# Altium schematic sheet RSPB-Tracker.SchDoc(U_RSPB-Tracker)
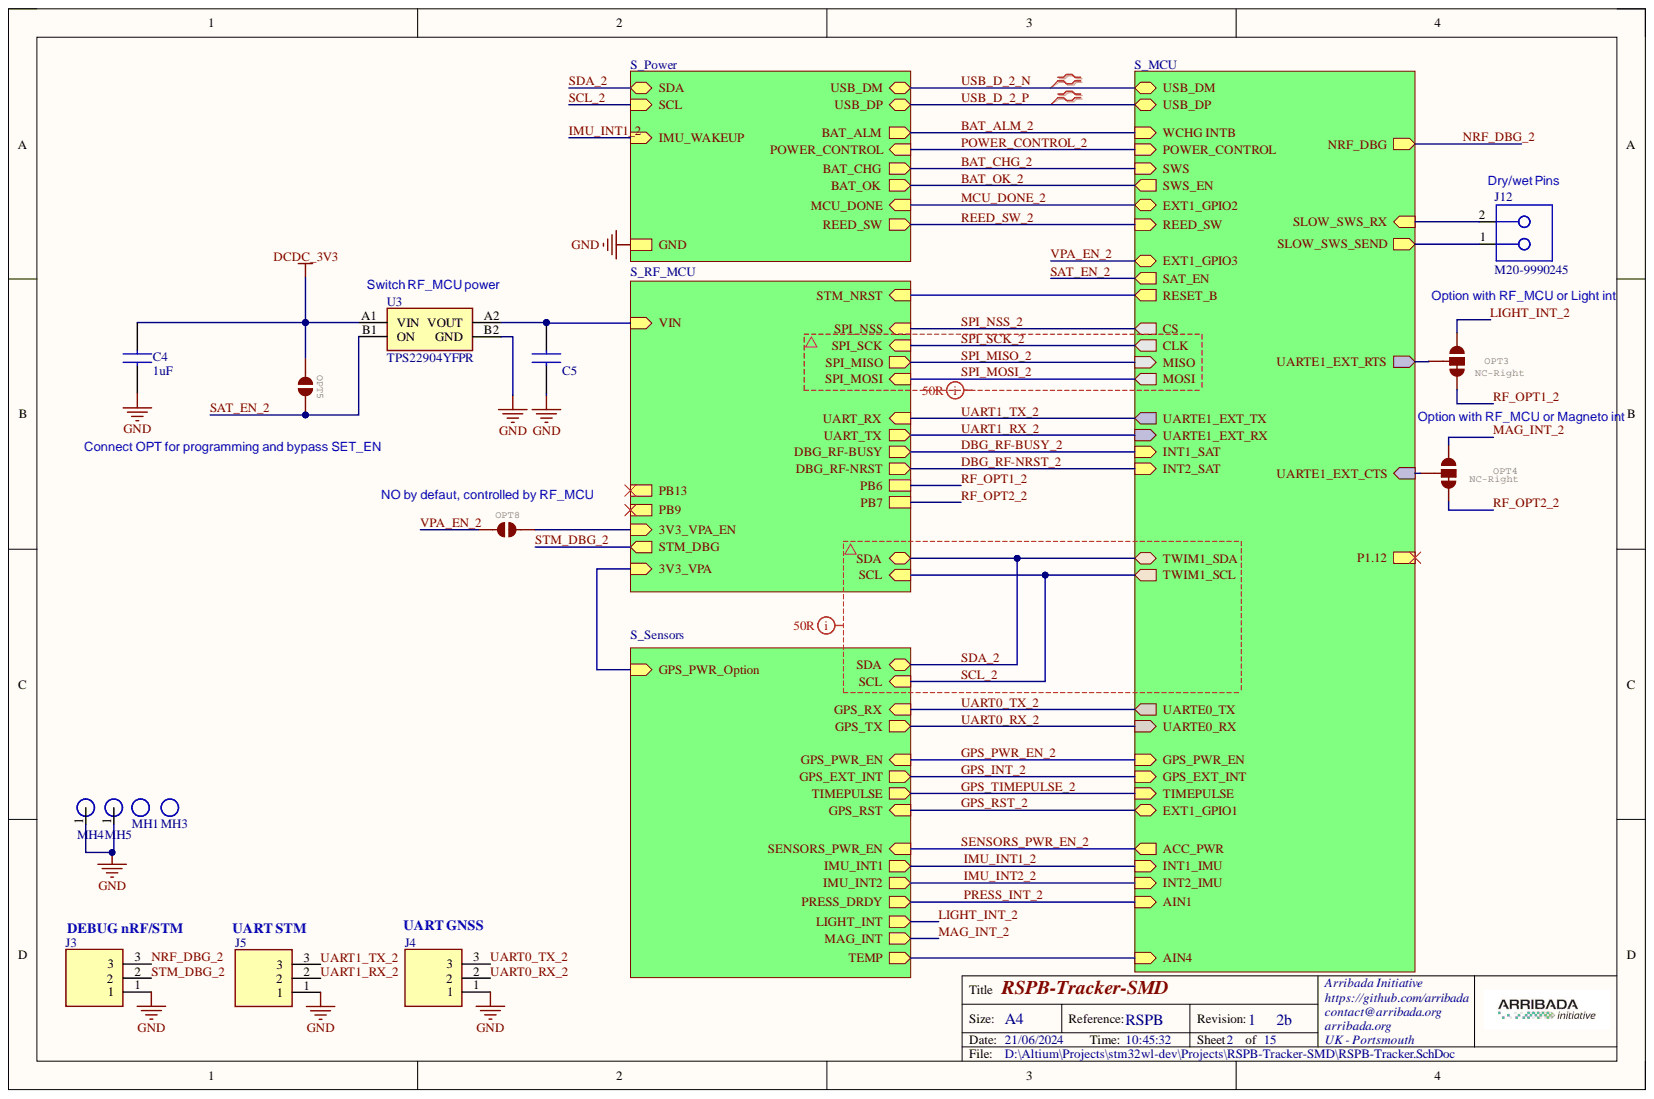
[diagram p0: RSPB-Tracker.SchDoc]

## Parts
C4  1uF  [CAPC1005X55N]
C5  GRM155R71C104KA88D  [0402]  (value not drawn)
J3  860-10-003-10-001101  [8601000310001101]  (value not drawn)
J4  860-10-003-10-001101  [8601000310001101]  (value not drawn)
J5  860-10-003-10-001101  [8601000310001101]  (value not drawn)
J12  M20-9990245  [HDR 2POS 2.54MM THRU HOLE]
MH1  Mounting Hole M2  [MOUNTING HOLE M2 UNPLATED]  (value not drawn)
MH3  Mounting Hole M2  [MOUNTING HOLE M2 UNPLATED]  (value not drawn)
MH4  Mounting Hole M2 Plated  [Mounting Hole M2 Plated]  (value not drawn)
MH5  Mounting Hole M2 Plated  [Mounting Hole M2 Plated]  (value not drawn)
OPT3  NC-Right  [SMT-JUMPER_3_2-NCRIGHT_TRACE_SILK]
OPT4  NC-Right  [SMT-JUMPER_3_2-NCRIGHT_TRACE_SILK]
OPT5  NO  [SMT-JUMPER_2_NO_TRACE_SILK]  (value not drawn)
OPT8  NO  [SMT-JUMPER_2_NO_TRACE_SILK]  (value not drawn)
U3  TPS22904YFPR  [BGA4C40P2X2_77X77X50]

## Nets
DCDC_3V3: C4.2 OPT5.1 U3.A1(VIN)
GND: C4.1 C5.1 J3.1 J4.1 J5.1 MH4.1 MH5.1 U3.B2(GND)
LIGHT_INT_2: OPT3.1
MAG_INT_2: OPT4.1
NRF_DBG_2: J3.3
RF_OPT1_2: OPT3.3
RF_OPT2_2: OPT4.3
SAT_EN_2: OPT5.2 U3.B1(ON)
STM_DBG_2: J3.2
UART0_RX_2: J4.2
UART0_TX_2: J4.3
UART1_RX_2: J5.2
UART1_TX_2: J5.3
VPA_EN_2: OPT8.1
NetC5_2: C5.2 U3.A2(VOUT)
NetJ12_1: J12.1
NetJ12_2: J12.2
NetOPT3_2: OPT3.2
NetOPT4_2: OPT4.2
NetOPT8_2: OPT8.2
3V3_VPA_2:
AIN4_2:
BAT_ALM_2:
BAT_CHG_2:
BAT_OK_2:
DBG_RF-BUSY_2:
DBG_RF-NRST_2:
GPS_INT_2:
GPS_PWR_EN_2:
GPS_RST_2:
GPS_TIMEPULSE_2:
IMU_INT1_2:
IMU_INT2_2:
MCU_DONE_2:
P1.12_2:
PB9_2:
PB13_2:
POWER_CONTROL_2:
PRESS_INT_2:
REED_SW_2:
RESET_B_2:
SCL_2:
SDA_2:
SENSORS_PWR_EN_2:
SPI_MISO_2:
SPI_MOSI_2:
SPI_NSS_2:
SPI_SCK_2:
USB_D_2_N:
USB_D_2_P:

## Library data of the parts
C4 = GRM155Z71A105KE01J: Multilayer Ceramic Capacitors MLCC - SMD/SMT 1.0UF 10V 10% 0402; Manufacturer 1: Murata; Manufacturer_Name: Murata Electronics; Description 1: Multi-Layer Ceramic Capacitor 1uF X7R 10% 0402 Paper T/R; Arrow Part Number: GRM155Z71A105KE01J; Arrow Price/Stock: https://www.arrow.com/en/products/grm155z71a105ke01j/murata-manufacturing?region=nac; Category 1: Ceramic Capacitors; Category 2: Ceramic Capacitors; Component Kind: Standard; Datasheet Link: http://www.murata.com/~/media/webrenewal/support/library/catalog/products/capacitor/mlcc/c02e.pdf; Description 2: Multi-Layer Ceramic Capacitor 1uF X7R 10% 0402 Paper T/R; Height: 0.55mm; Library Name: stm32wl-dev.SchLib; Manufacturer 2: Murata; Manufacturer Part Number 1: GRM155Z71A105KE01J; Manufacturer Part Number 2: GRM155Z71A105KE01J; Manufacturer_Part_Number: GRM155Z71A105KE01J; Mouser Part Number: 81-GRM155Z71A105KE1J; Mouser Price/Stock: https://www.mouser.co.uk/ProductDetail/Murata-Electronics/GRM155Z71A105KE01J?qs=xZ%2FP%252Ba9zWqaF%2FmyRgV0iOA%3D%3D; Pricing 1: 1=0,1, 10=0,069, 50=0,069, 100=0,031, 1000=0,018, 10000=0,013 (USD); Pricing 2: 1=0,1, 10=0,063, 50=0,0344, 100=0,0281, 500=0,02006, 1000=0,01577, 2500=0,01433, 5000=0,01319 (USD); RoHS 1: Yes; RoHS 2: Yes; Stock 1: 804340; Stock 2: 80025; Supplier 1: Mouser; Supplier 2: Digi-Key; Supplier Part Number 1: 81-GRM155Z71A105KE1J; Supplier Part Number 2: 490-GRM155Z71A105KE01JCT-ND; Value: 1uF
C5 = GRM155R71C104KA88D: Multilayer Ceramic Capacitors MLCC - SMD/SMT 0.1 uF 16 VDC 10% 0402 X7R; Manufacturer: YAGEO; Manufacturer 1: Murata; Manufacturer_Part_Number: CC0402KRX7R7BB104; Capacitance: 100nF; Description 1: 0402 0.1 uF 16V ±10 % Tolerance X7R SMT Multilayer Ceramic Capacitor; Value: 100nF; Voltage: 16V; Case Code (Imperial): 0402; Case Code (Metric): 1005; Case/Package: 0402; Category 1: Ceramic Capacitors; Component Kind: Standard; ComponentLink1Description: https://datasheet.ciiva.com/129/grm155r71c104ka88-01-129739.pdf?src-supplier=Digi-Key; ComponentLink1URL: https://datasheet.ciiva.com/129/grm155r71c104ka88-01-129739.pdf?src-supplier=Digi-Key; ComponentLink2Description: https://datasheet.ciiva.com/129/grm155r71c104ka88-01-129740.pdf?src-supplier=Digi-Key; ComponentLink2URL: https://datasheet.ciiva.com/129/grm155r71c104ka88-01-129740.pdf?src-supplier=Digi-Key; ComponentLink3Description: https://datasheet.ciiva.com/29199/454098-da-01-en-vs-kondensator-0402-29199799.pdf?src-supplier=Conrad; ComponentLink3URL: https://datasheet.ciiva.com/29199/454098-da-01-en-vs-kondensator-0402-29199799.pdf?src-supplier=Conrad; ComponentLink4Description: https://datasheet.ciiva.com/7937/4360-7937615.pdf?src-supplier=Element14; ComponentLink4URL: https://datasheet.ciiva.com/7937/4360-7937615.pdf?src-supplier=Element14; ComponentLink5Description: https://datasheet.ciiva.com/14116/grmseries-highdielectrictype-eng-14116878.pdf?src-supplier=Distrelec; ComponentLink5URL: https://datasheet.ciiva.com/14116/grmseries-highdielectrictype-eng-14116878.pdf?src-supplier=Distrelec; ComponentLink6Description: https://datasheet.ciiva.com/14103/grmseries-highdielectrictype-eng-14103750.pdf?src-supplier=Distrelec; ComponentLink6URL: https://datasheet.ciiva.com/14103/grmseries-highdielectrictype-eng-14103750.pdf?src-supplier=Distrelec; ComponentLink7Description: https://datasheet.ciiva.com/28534/4360-28534127.pdf?src-supplier=Element14; ComponentLink7URL: https://datasheet.ciiva.com/28534/4360-28534127.pdf?src-supplier=Element14; ComponentLink8Description: https://datasheet.ciiva.com/28534/4360-28534133.pdf?src-supplier=Element14; ComponentLink8URL: https://datasheet.ciiva.com/28534/4360-28534133.pdf?src-supplier=Element14; Depth: 0.5mm; Dielectric: X7R; Dielectric Material: Ceramic; Height: 0.5mm; Lead Free: Lead Free; Length: 1mm; Library Name: stm32wl-dev.SchLib; Manufacturer Part Number 1: GRM155R71C104KA88D; Material: Ceramic; Max Operating Temperature: 125°C; Min Operating Temperature: -55°C; Mount: Surface Mount; Number of Pins: 2; Package: C0402; Package Quantity: 1; Packaging: Tape and Reel; Pricing 1: 1=0,1, 10=0,017, 50=0,017, 100=0,01, 1000=0,008, 10000=0,005 (USD); Radiation Hardening: No; Reach SVHC Compliant: Unknown; RoHS 1: Yes; RoHS Compliant: Yes; Series: GRM; Stock 1: 7816691; Supplier 1: Mouser; Supplier Part Number 1: 81-GRM155R71C104KA88; Termination: SMD/SMT; Thickness: 0.022inch; Tolerance: 10%; Voltage Rating: 16V; Voltage Rating (DC): 16V; Width: 0.02inch
J3-J5 = 860-10-003-10-001101: Connector, Header 3 pos, 1 row, pitch 1mm; Manufacturer_Name: Preci-Dip; Component Kind: Standard; Datasheet Link: https://www.precidip.com/en/Products/PCB-Connectors/pview/860-10-NNN-10-001101.html#; Height: 3.63mm; Library Name: stm32wl-dev.SchLib; Manufacturer_Part_Number: 860-10-003-10-001101; Mouser Part Number: 437-8601000310001101; Mouser Price/Stock: https://www.mouser.co.uk/ProductDetail/Preci-dip/860-10-003-10-001101?qs=jrVTBkKbt4ZfyeZ%252Bg9GDNA%3D%3D
J12 = M20-9990245: CON HDR 2POS HEADER 2.54MM VERT THRU HOLE; Manufacturer: Harwin; Component Kind: Standard; Distributor 1: EBV; Distributor 2: Future Electronics; Library Name: Connectors.SchLib; Manufacturers Part Number: M20-9990245; Supplier 1: Digi-Key; Supplier 2: Mouser; Supplier Part Number 1: 952-2261-ND; Supplier Part Number 2: 855-M20-9990245
MH1 MH3 = Mounting Hole M2: Manufacturer: NOT FITTED PART; Component Kind: Standard; Description: Mounting Hole M2; Distributor 1: EBV; Distributor 2: Future Electronics; Library Name: Misc.SchLib; Manufacturers Part Number: NOT FITTED PART
MH4 MH5 = Mounting Hole M2 Plated: Manufacturer: NOT FITTED PART; Component Kind: Standard; Description: Mounting Hole M2 Plated; Distributor 1: EBV; Distributor 2: Future Electronics; Library Name: Misc.SchLib; Manufacturers Part Number: NOT FITTED PART
OPT3 OPT4 = JUMPER-SMT_3_2-NCRIGHT_TRACE_SILK: Normally closed trace jumper (1 of 2 connections)      This jumper has a trace between Right pad so they are normally opened right (NCLEFT). Use a razor knife to open the connection(s). For best results follow the IPC guidelines for cutting traces: Cutout at least 0.063 mm (0.005 in).  Remove all loose material to clean up the cut area.  Seal the cut with an approved epoxy.       Reapply solder to reclose the connection(s).; DeviceName: _SILK; DeviceSetName: JUMPER-SMT_3_2-NC_TRACE; GateName_1: G$1; SymbolName_1: SMT-JUMPER_3_2-NC_TRACE; Component Kind: Standard; Library Name: stm32wl-dev.SchLib; LibraryName: SparkFun-Jumpers
OPT5 OPT8 = JUMPER-SMT_2_NO_TRACE_SILK_1: Normally opened trace jumper      This jumper has no trace between two pads so it's normally closed (NO).; DeviceName: _SILK; DeviceSetName: JUMPER-SMT_2_NC_TRACE; GateName_1: G$1; SymbolName_1: SMT-JUMPER_2_NC_TRACE; Component Kind: Standard; Library Name: stm32wl-dev.SchLib; LibraryName: SparkFun-Jumpers
U3 = TPS22904YFPR: 3.6-V, 0.5-A, 66-mΩ load switch with output discharge 4-DSBGA -40 to 85; Manufacturer: Texas Instruments; Category: Hot Swap Controllers; Component Kind: Standard; ComponentLink1Description: https://datasheet.ciiva.com/15109/getdatasheetpartid-534261-15109132.pdf?src-supplier=Verical; ComponentLink1URL: https://datasheet.ciiva.com/15109/getdatasheetpartid-534261-15109132.pdf?src-supplier=Verical; ComponentLink2Description: https://datasheet.ciiva.com/3232/tps22903-3232832.pdf?src-supplier=Avnet; ComponentLink2URL: https://datasheet.ciiva.com/3232/tps22903-3232832.pdf?src-supplier=Avnet; ComponentLink3Description: https://datasheet.ciiva.com/41462/001014702-da-01-en-ic-load-switch-tps22904yfpr-dsbga-4-tid-41462548.pdf?src-supplier=Conrad; ComponentLink3URL: https://datasheet.ciiva.com/41462/001014702-da-01-en-ic-load-switch-tps22904yfpr-dsbga-4-tid-41462548.pdf?src-supplier=Conrad; ComponentLink4Description: https://datasheet.ciiva.com/3233/tps22903-3233560.pdf?src-supplier=Avnet; ComponentLink4URL: https://datasheet.ciiva.com/3233/tps22903-3233560.pdf?src-supplier=Avnet; ComponentLink5Description: https://datasheet.ciiva.com/1963/tps22903-1963475.pdf?src-supplier=Digikey; ComponentLink5URL: https://datasheet.ciiva.com/1963/tps22903-1963475.pdf?src-supplier=Digikey; ComponentLink6Description: https://datasheet.ciiva.com/1963/tps22903-1963476.pdf?src-supplier=Digikey; ComponentLink6URL: https://datasheet.ciiva.com/1963/tps22903-1963476.pdf?src-supplier=Digikey; ComponentLink7Description: https://datasheet.ciiva.com/1963/tps22903-1963477.pdf?src-supplier=Digikey; ComponentLink7URL: https://datasheet.ciiva.com/1963/tps22903-1963477.pdf?src-supplier=Digikey; Library Name: stm32wl-dev.SchLib; Manufacturer Part Number: TPS22904YFPR; Pricing 1: 1=0,84, 10=0,7, 50=0,7, 100=0,528, 1000=0,356, 10000=0,288 (USD); RoHS: Yes; Stock 1: 2747; Supplier 1: Mouser; Supplier Part Number 1: 595-TPS22904YFPR
